# Altium schematic sheet MecanumTb66212 - 副本.SchDoc
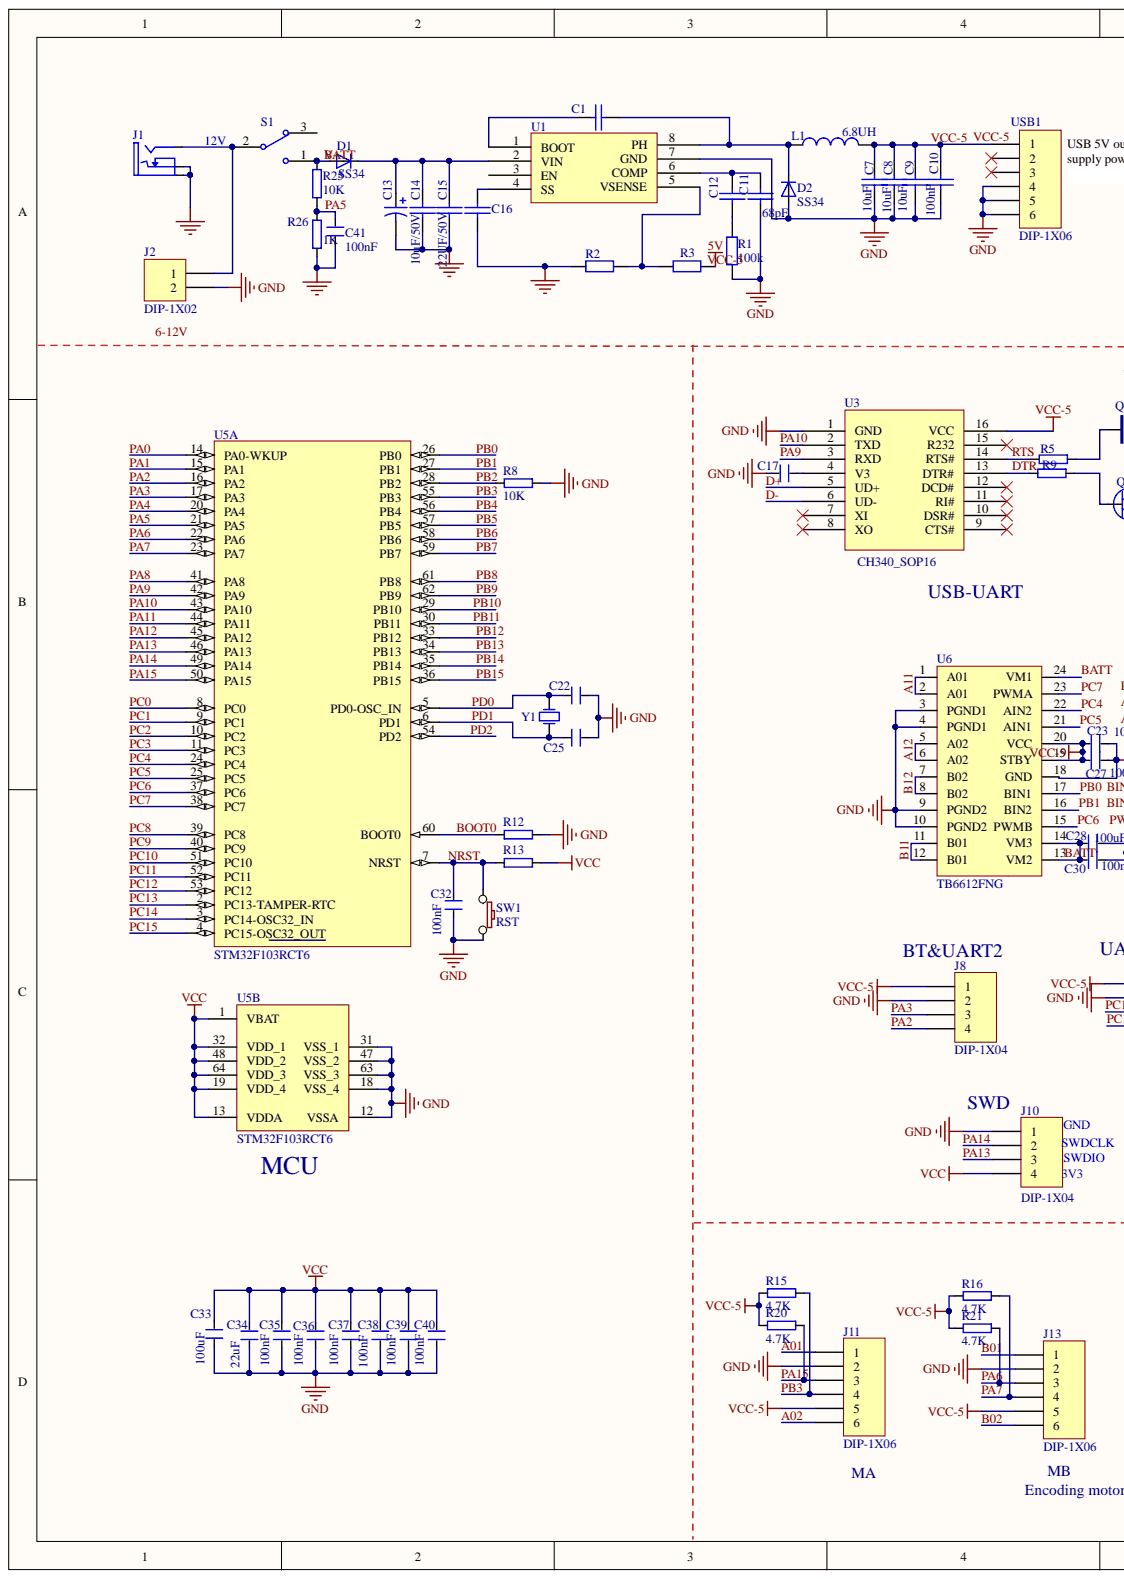
[diagram p0: MecanumTb66212 - 副本.SchDoc, left]
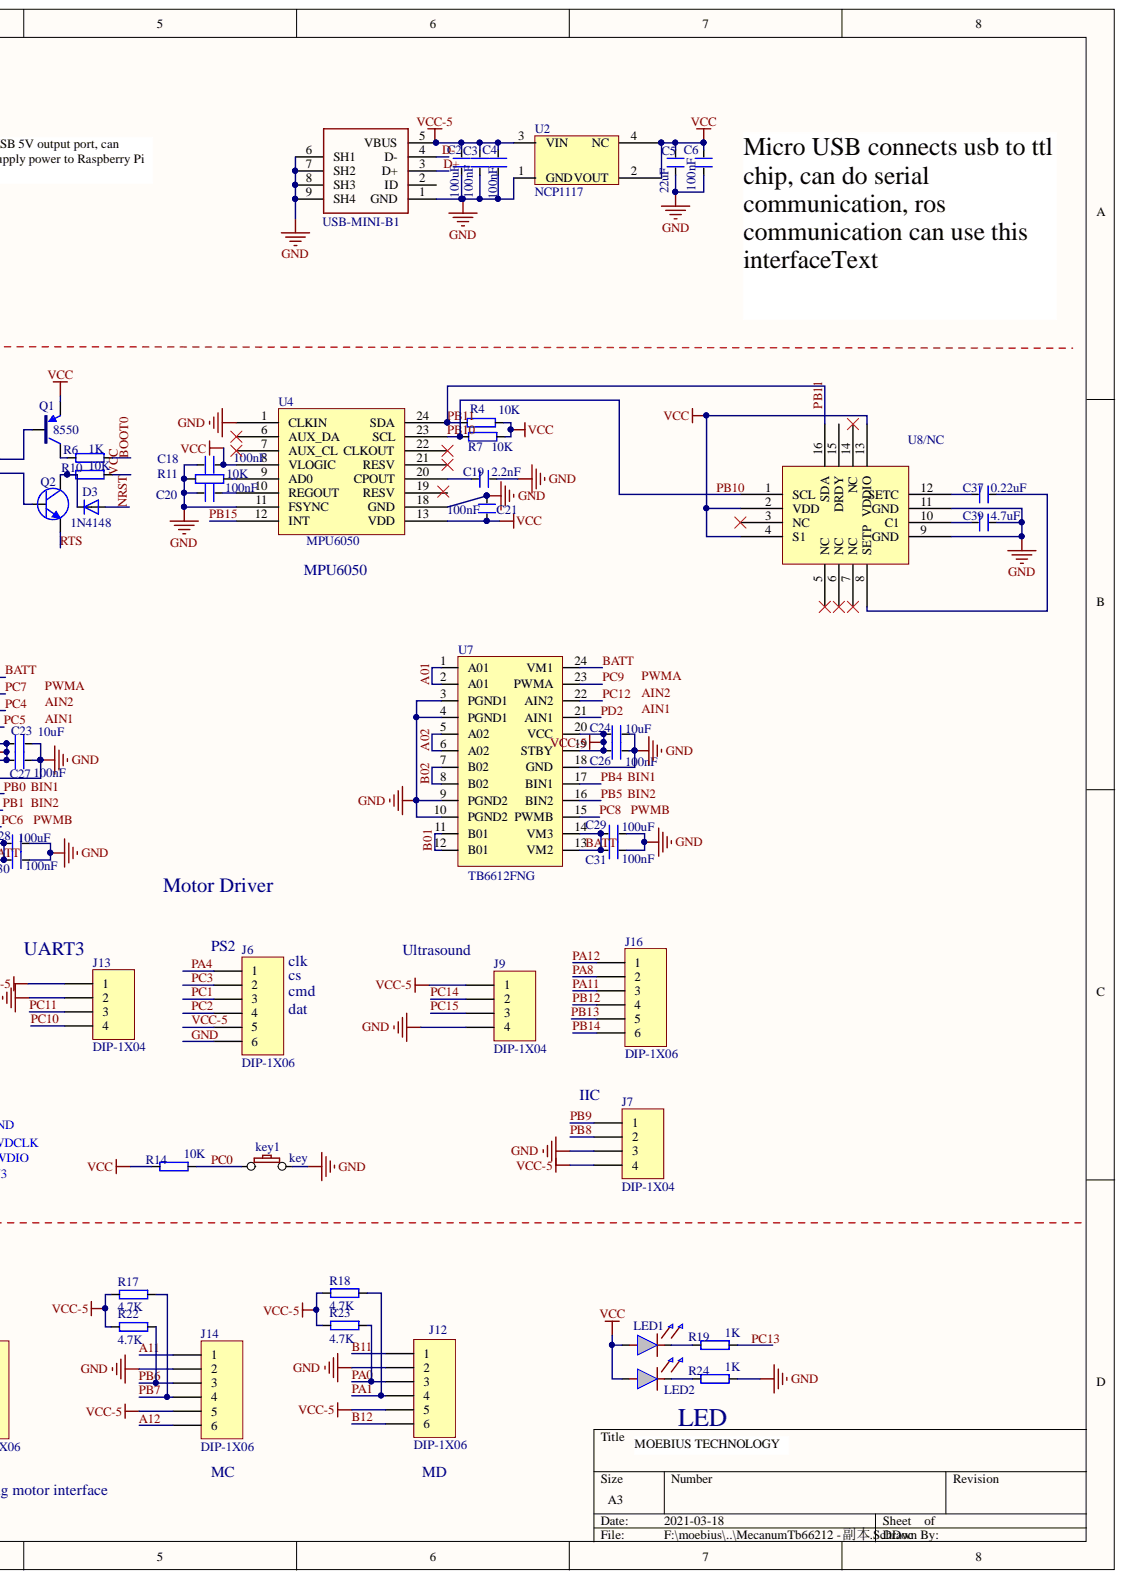
[diagram p1: MecanumTb66212 - 副本.SchDoc, right]

## Parts
C1  100nF  [C0603]  (value not drawn)
C2  100uF  [1206C]
C3  100nF  [0603C]
C4  100nF  [0603C]
C5  22uF  [0805C]
C6  100nF  [0603C]
C7  10uF  [C0603]
C8  10uF  [C0603]
C9  10uF  [C0603]
C10  100nF  [C0603]
C11  68pF  [C0603]
C12  120pF  [C0603]  (value not drawn)
C13  100uF  [C8X8]  (value not drawn)
C14  10uF/50V  [C0603]
C15  22UF/50V  [C0603]
C16  10nF  [C0805]  (value not drawn)
C17  10nF  [0603C]  (value not drawn)
C18  100nF  [0603C]
C19  2.2nF  [0603C]
C20  100nF  [0603C]
C21  100nF  [0603C]
C22  20pF  [0603C]  (value not drawn)
C23  10uF  [0603C]
C24  10uF  [0603C]
C25  20pF  [0603C]  (value not drawn)
C26  100nF  [0603C]
C27  100nF  [0603C]
C28  100uF  [0805C]
C29  100uF  [0805C]
C30  100nF  [0805C]
C31  100nF  [0805C]
C32  100nF  [0603C]
C33  100uF  [C6.3X6.3]
C34  22uF  [1206C]
C35  100nF  [0603C]
C36  100nF  [0603C]
C37  0.22uF  [0603C]
C37  100nF  [0603C]
C38  100nF  [0603C]
C39  4.7uF  [0603C]
C39  100nF  [0603C]
C40  100nF  [0603C]
C41  100nF  [0603C]
D1  SS34  [SMA]
D2  SS34  [SMA]
D3  1N4148  [0603LED]
J1  DC-JACK  [DC-6mm]  (value not drawn)
J2  DIP-1X02  [HX5.08-2L]
J6  DIP-1X06  [HDR1X6]
J7  DIP-1X04  [HDR1X4]
J8  DIP-1X04  [HDR1X4]
J9  DIP-1X04  [HDR1X4]
J10  DIP-1X04  [HDR1X4]
J11  DIP-1X06  [HDR1X6]
J12  DIP-1X06  [HDR1X6]
J13  DIP-1X06  [HDR1X6]
J13  DIP-1X04  [HDR1X4]
J14  DIP-1X06  [HDR1X6]
J16  DIP-1X06  [HDR1X6]
key1  key  [3.6*2.5-SWITCH]
L1  L  value 6.8UH  [L7X7]
LED1  RED_RX  [0603LED G]  (value not drawn)
LED2  RED_RX  [0603LED R]  (value not drawn)
Q1  8550  [SOT23-3-TCM809]
Q2  8050  [AP2306]  (value not drawn)
R1  100k  [R0603]
R2  1.91K  [R0603]  (value not drawn)
R3  10k  [R0603]  (value not drawn)
R4  10K  [0603R]
R5  1K  [0603R]  (value not drawn)
R6  1K  [0603R]
R7  10K  [0603R]
R8  10K  [0603R]
R9  1K  [0603R]  (value not drawn)
R10  10K  [0603R]
R11  10K  [0603R]
R12  10K  [0603R]  (value not drawn)
R13  10K  [0603R]  (value not drawn)
R14  10K  [0603R]
R15  4.7K  [0603R]
R16  4.7K  [0603R]
R17  4.7K  [0603R]
R18  4.7K  [0603R]
R19  1K  [0603R]
R20  4.7K  [0603R]
R21  4.7K  [0603R]
R22  4.7K  [0603R]
R23  4.7K  [0603R]
R24  1K  [0603R]
R25  10K  [0603R]
R26  1K  [0603R]
S1  SW-SPDT  [3PIN-5.08]  (value not drawn)
SW1  RST  [3.6*2.5-SWITCH]
U1  TPSS54331  [SOP8]  (value not drawn)
U2  NCP1117  [SOT223-NCP1117]
U3  CH340_SOP16  [SOP16-MAX3232]
U4  MPU6050  [QFN24-MPU6050]
U5  STM32F103RCT6  [LC-LQFP-64_10x10x05P]  (parts A,B)
U6  TB6612FNG  [SSOP24]
U7  TB6612FNG  [SSOP24]
U8/NC  HMC5883L  [LPCC16-HMC5883L]  (value not drawn)
USB1  DIP-1X06  [DIP-1X06-2.54]
USB-MINI-B1  USB-MINI-B  [USB_MICRO]
Y1  8M  [OSC]  (value not drawn)

## Nets
5V: R3.2
12V: J1.1 J2.1 S1.2
A01: J11.1 U7.1(A01) U7.2(A01)
A02: J11.6 U7.5(A02) U7.6(A02)
A11: J14.1 U6.1(A01) U6.2(A01)
A12: J14.6 U6.5(A02) U6.6(A02)
B01: J13.1 U7.11(B01) U7.12(B01)
B02: J13.6 U7.7(B02) U7.8(B02)
B11: J12.1 U6.11(B01) U6.12(B01)
B12: J12.6 U6.7(B02) U6.8(B02)
BATT: C28.2 C29.2 C30.2 C31.2 D1.1 R25.1 S1.1 U6.13(VM2) U6.14(VM3) U6.24(VM1) U7.13(VM2) U7.14(VM3) U7.24(VM1)
BOOT0: R6.1 R12.1 U5.60(BOOT0)
D+: U3.5(UD+) USB-MINI-B1.3(D+)
D-: U3.6(UD-) USB-MINI-B1.4(D-)
DTR: R9.2 U3.13(DTR#)
GND: C2.1 C3.1 C4.1 C5.1 C6.1 C7.1 C8.1 C9.1 C10.1 C11.2 C13.2 C14.2 C15.2 C16.2 C17.2 C18.2 C19.1 C20.2 C21.2 C22.1 C23.1 C24.1 C25.1 C26.1 C27.1 C28.1 C29.1 C30.1 C31.1 C32.1 C33.2 C34.1 C35.1 C36.1 C37.1 C38.1 C39.1 C40.1 C41.1 D2.1 J1.2 J1.3 J2.2 J6.6 J7.3 J8.2 J9.4 J10.1 J11.2 J12.2 J13.2 J14.2 key1.2 R1.1 R2.2 R8.1 R11.2 R12.2 R24.1 R26.2 SW1.2 U1.7(GND) U2.1(GND) U3.1(GND) U4.1(CLKIN) U4.11(FSYNC) U4.18(GND) U5.12(VSSA) U5.18(VSS_4) U5.31(VSS_1) U5.47(VSS_2) U5.63(VSS_3) U6.3(PGND1) U6.4(PGND1) U6.9(PGND2) U6.10(PGND2) U6.18(GND) U7.3(PGND1) U7.4(PGND1) U7.9(PGND2) U7.10(PGND2) U7.18(GND) U8/NC.9(GND) U8/NC.11(GND) USB1.4 USB1.5 USB1.6 USB-MINI-B1.1(GND) USB-MINI-B1.6(SH1) USB-MINI-B1.7(SH2) USB-MINI-B1.8(SH3) USB-MINI-B1.9(SH4)
NRST: C32.2 D3.1 R13.1 SW1.1 U5.7(NRST)
PA0: J12.3 R23.1 U5.14(PA0-WKUP)
PA1: J12.4 R18.1 U5.15(PA1)
PA2: J8.4 U5.16(PA2)
PA3: J8.3 U5.17(PA3)
PA4: J6.1 U5.20(PA4)
PA5: C41.2 R25.2 R26.1 U5.21(PA5)
PA6: J13.3 R21.1 U5.22(PA6)
PA7: J13.4 R16.1 U5.23(PA7)
PA8: J16.2 U5.41(PA8)
PA9: U3.3(RXD) U5.42(PA9)
PA10: U3.2(TXD) U5.43(PA10)
PA11: J16.3 U5.44(PA11)
PA12: J16.1 U5.45(PA12)
PA13: J10.3 U5.46(PA13)
PA14: J10.2 U5.49(PA14)
PA15: J11.3 R20.1 U5.50(PA15)
PB0: U5.26(PB0) U6.17(BIN1)
PB1: U5.27(PB1) U6.16(BIN2)
PB2: R8.2 U5.28(PB2)
PB3: J11.4 R15.1 U5.55(PB3)
PB4: U5.56(PB4) U7.17(BIN1)
PB5: U5.57(PB5) U7.16(BIN2)
PB6: J14.3 R22.1 U5.58(PB6)
PB7: J14.4 R17.1 U5.59(PB7)
PB8: J7.2 U5.61(PB8)
PB9: J7.1 U5.62(PB9)
PB10: R7.2 U4.23(SCL) U5.29(PB10) U8/NC.1(SCL)
PB11: R4.2 U4.24(SDA) U5.30(PB11) U8/NC.16(SDA)
PB12: J16.4 U5.33(PB12)
PB13: J16.5 U5.34(PB13)
PB14: J16.6 U5.35(PB14)
PB15: U4.12(INT) U5.36(PB15)
PC0: key1.1 R14.1 U5.8(PC0)
PC1: J6.3 U5.9(PC1)
PC2: J6.4 U5.10(PC2)
PC3: J6.2 U5.11(PC3)
PC4: U5.24(PC4) U6.22(AIN2)
PC5: U5.25(PC5) U6.21(AIN1)
PC6: U5.37(PC6) U6.15(PWMB)
PC7: U5.38(PC7) U6.23(PWMA)
PC8: U5.39(PC8) U7.15(PWMB)
PC9: U5.40(PC9) U7.23(PWMA)
PC10: J13.4 U5.51(PC10)
PC11: J13.3 U5.52(PC11)
PC12: U5.53(PC12) U7.22(AIN2)
PC13: R19.1 U5.2(PC13-TAMPER-RTC)
PC14: J9.2 U5.3(PC14-OSC32_IN)
PC15: J9.3 U5.4(PC15-OSC32_OUT)
PD0: C22.2 U5.5(PD0-OSC_IN) Y1.2
PD1: C25.2 U5.6(PD1) Y1.1
PD2: U5.54(PD2) U7.21(AIN1)
RTS: Q2.2 R5.2 U3.14(RTS#)
VCC: C5.2 C6.2 C18.1 C21.1 C33.1 C34.2 C35.2 C36.2 C37.2 C38.2 C39.2 C40.2 J10.4 LED1.1 LED2.1 Q1.3 R4.1 R7.1 R10.1 R13.2 R14.2 U2.2(VOUT) U2.4(NC) U4.8(VLOGIC) U4.13(VDD) U5.1(VBAT) U5.13(VDDA) U5.19(VDD_4) U5.32(VDD_1) U5.48(VDD_2) U5.64(VDD_3) U8/NC.2(VDD) U8/NC.4(S1) U8/NC.13(VDDIO)
VCC-5: C2.2 C3.2 C4.2 C7.2 C8.2 C9.2 C10.2 C23.2 C24.2 C26.2 C27.2 J6.5 J7.4 J8.1 J9.1 J11.5 J12.5 J13.1 J13.5 J14.5 L1.2 R15.2 R16.2 R17.2 R18.2 R20.2 R21.2 R22.2 R23.2 U2.3(VIN) U3.16(VCC) U6.19(STBY) U6.20(VCC) U7.19(STBY) U7.20(VCC) USB1.1 USB-MINI-B1.5(VBUS)
NetC1_1: C1.1 U1.1(BOOT)
NetC1_2: C1.2 D2.2 L1.1 U1.8(PH)
NetC11_1: C11.1 C12.1 U1.6(COMP)
NetC12_2: C12.2 R1.2
NetC13_1: C13.1 C14.1 C15.1 D1.2 U1.2(VIN)
NetC16_1: C16.1 U1.4(SS)
NetC17_1: C17.1 U3.4(V3)
NetC19_2: C19.2 U4.20(CPOUT)
NetC20_1: C20.1 U4.10(REGOUT)
NetC37_1: C37.1 U8/NC.8(SETP)
NetC37_2: C37.2 U8/NC.12(SETC)
NetC39_2: C39.2 U8/NC.10(C1)
NetD3_2: D3.2 Q2.3 R10.2
NetLED1_2: LED1.2 R19.2
NetLED2_2: LED2.2 R24.2
NetQ1_1: Q1.1 R5.1
NetQ1_2: Q1.2 R6.2
NetQ2_1: Q2.1 R9.1
NetR2_1: R2.1 R3.1 U1.5(VSENSE)
NetR11_1: R11.1 U4.9(AD0)
3V3:
6-12V:
AIN1:
AIN2:
BIN1:
BIN2:
N00023:
PWMA:
PWMB:
SWDCLK:
SWDIO:
VCC-5V:

Not connected: S1 3; U1 3(EN); U3 7(XI) 8(XO) 9(CTS#) 10(DSR#) 11(RI#) 12(DCD#) 15(R232); U4 6(AUX_DA) 7(AUX_CL) 19(RESV) 21(RESV) 22(CLKOUT); U8/NC 3(NC) 5(NC) 6(NC) 7(NC) 14(NC) 15(DRDY); USB1 2 3; USB-MINI-B1 2(ID)

## Library data of the parts
C1 C7-C12 C14-C16 = CAP: Capacitor; Component Kind: Standard
C2-C6 C17-C37 C37-C39 C39-C41 = Cap: Capacitor; Component Kind: Standard; Library Name: accessory.SchLib
C13 = Cap Pol1: Polarized Capacitor (Radial); Value: 100F; Component Kind: Standard; LatestRevisionDate: 17-Jul-2002; LatestRevisionNote: Re-released for DXP Platform.; Library Name: Miscellaneous Devices.IntLib; PackageDescription: Polarized Capacitor (Radial); 2 Leads; PackageReference: RB7.6-15; Published: 8-Jun-2000; Simulation: CAP; Publisher: Altium Limited
D1-D3 = DIODE: Component Kind: Standard; Library Name: accessory.SchLib
J2 = DIP-1X02: Header, 2-Pin; Component Kind: Standard; LatestRevisionDate: 17-Jul-2002; LatestRevisionNote: Re-released for DXP Platform.; Library Name: connector.SchLib; Publisher: Altium Limited
J6 J11-J14 J16 USB1 = DIP-1X06: Header, 6-Pin; Component Kind: Standard; LatestRevisionDate: 17-Jul-2002; LatestRevisionNote: Re-released for DXP Platform.; Library Name: connector.SchLib; Publisher: Altium Limited
J7-J10 J13 = DIP-1X04: Header, 4-Pin; Component Kind: Standard; LatestRevisionDate: 17-Jul-2002; LatestRevisionNote: Re-released for DXP Platform.; Library Name: connector.SchLib; Publisher: Altium Limited
key1 SW1 = BT: Component Kind: Standard; Library Name: accessory.SchLib
L1 = L: Inductor; Code_IEC: 1005; Code_JEITA: 0402; Component Kind: Standard; LatestRevisionDate: 29-May-2009; LatestRevisionNote: IPC-7351 Footprint Added.; PackageDescription: Chip Inductor; PackageReference: 0402-A; Published: 8-Jun-2000; Simulation: INDUCTOR; Library Name: accessory.SchLib; Publisher: Altium Limited
LED1 LED2 = LED: Component Kind: Standard; Library Name: accessory.SchLib
Q1 = PNP: Component Kind: Standard; Library Name: accessory.SchLib
Q2 = NPN: Component Kind: Standard; Library Name: accessory.SchLib
R1 = Res2: Resistor; Component Kind: Standard; LatestRevisionDate: 17-Jul-2002; LatestRevisionNote: Re-released for DXP Platform.; Library Name: Miscellaneous Devices.IntLib; PackageDescription: Axial Device, Thru-Hole; 2 Leads; 0.4 in Pin Spacing; PackageReference: AXIAL-0.4; Published: 8-Jun-2000; Simulation: RESISTOR; Value: 100K; Publisher: Altium Limited
R2 R3 = Res2: Resistor; Value: 100K; Component Kind: Standard; LatestRevisionDate: 17-Jul-2002; LatestRevisionNote: Re-released for DXP Platform.; Library Name: Miscellaneous Devices.IntLib; PackageDescription: Axial Device, Thru-Hole; 2 Leads; 0.4 in Pin Spacing; PackageReference: AXIAL-0.4; Published: 8-Jun-2000; Simulation: RESISTOR; Publisher: Altium Limited
R4-R26 = Res: Resistor; Component Kind: Standard; Library Name: accessory.SchLib
S1 = SW-SPDT: SPDT Subminiature Toggle Switch, Right Angle Mounting, Vertical Actuation; Component Kind: Standard; ComponentLink1Description: Manufacturer Link; ComponentLink1URL: http://www.apem.com; DatasheetVersion: 05-Dec-2000; LatestRevisionDate: 17-Dec-2003; LatestRevisionNote: Schematic revised, PCB Footprint replaced.; Library Name: Miscellaneous Devices.IntLib; PackageDescription: Switch; 4 Leads; PackageReference: TL36WW15050; Published: 24-Mar-1999; Publisher: Altium Limited
U5 = STM32F103RCT6: ARM; Component Kind: Standard; ComponentLink1Description: 供应商链接; ComponentLink1URL: http://www.szlcsc.com/product/details_8815.html; Library Name: MCU.SchLib; Package: LQFP-64_10x10x05P; Supplier: LC; SuppliersPartNumber: C8323
U6 U7 = TB6612: Component Kind: Standard; Library Name: chip1.SchLib
Y1 = OSC: Component Kind: Standard; Library Name: accessory.SchLib
J1 = DC-JACK: Component Kind: Standard; Library Name: connector.SchLib
U1 = TPSS54331: Component Kind: Standard; Library Name: chip1.SchLib
U2 = NCP1117: Component Kind: Standard; Library Name: chip1.SchLib
U3 = CH340_SOP16: Component Kind: Standard; Library Name: chip1.SchLib
U4 = MPU6050: Component Kind: Standard; Library Name: chip1.SchLib
U8/NC = HMC5883L: Component Kind: Standard; Library Name: chip1.SchLib
USB-MINI-B1 = USB-MINI-B: Component Kind: Standard; Library Name: connector.SchLib
